# Altium schematic sheet EPS_connector.SchDoc
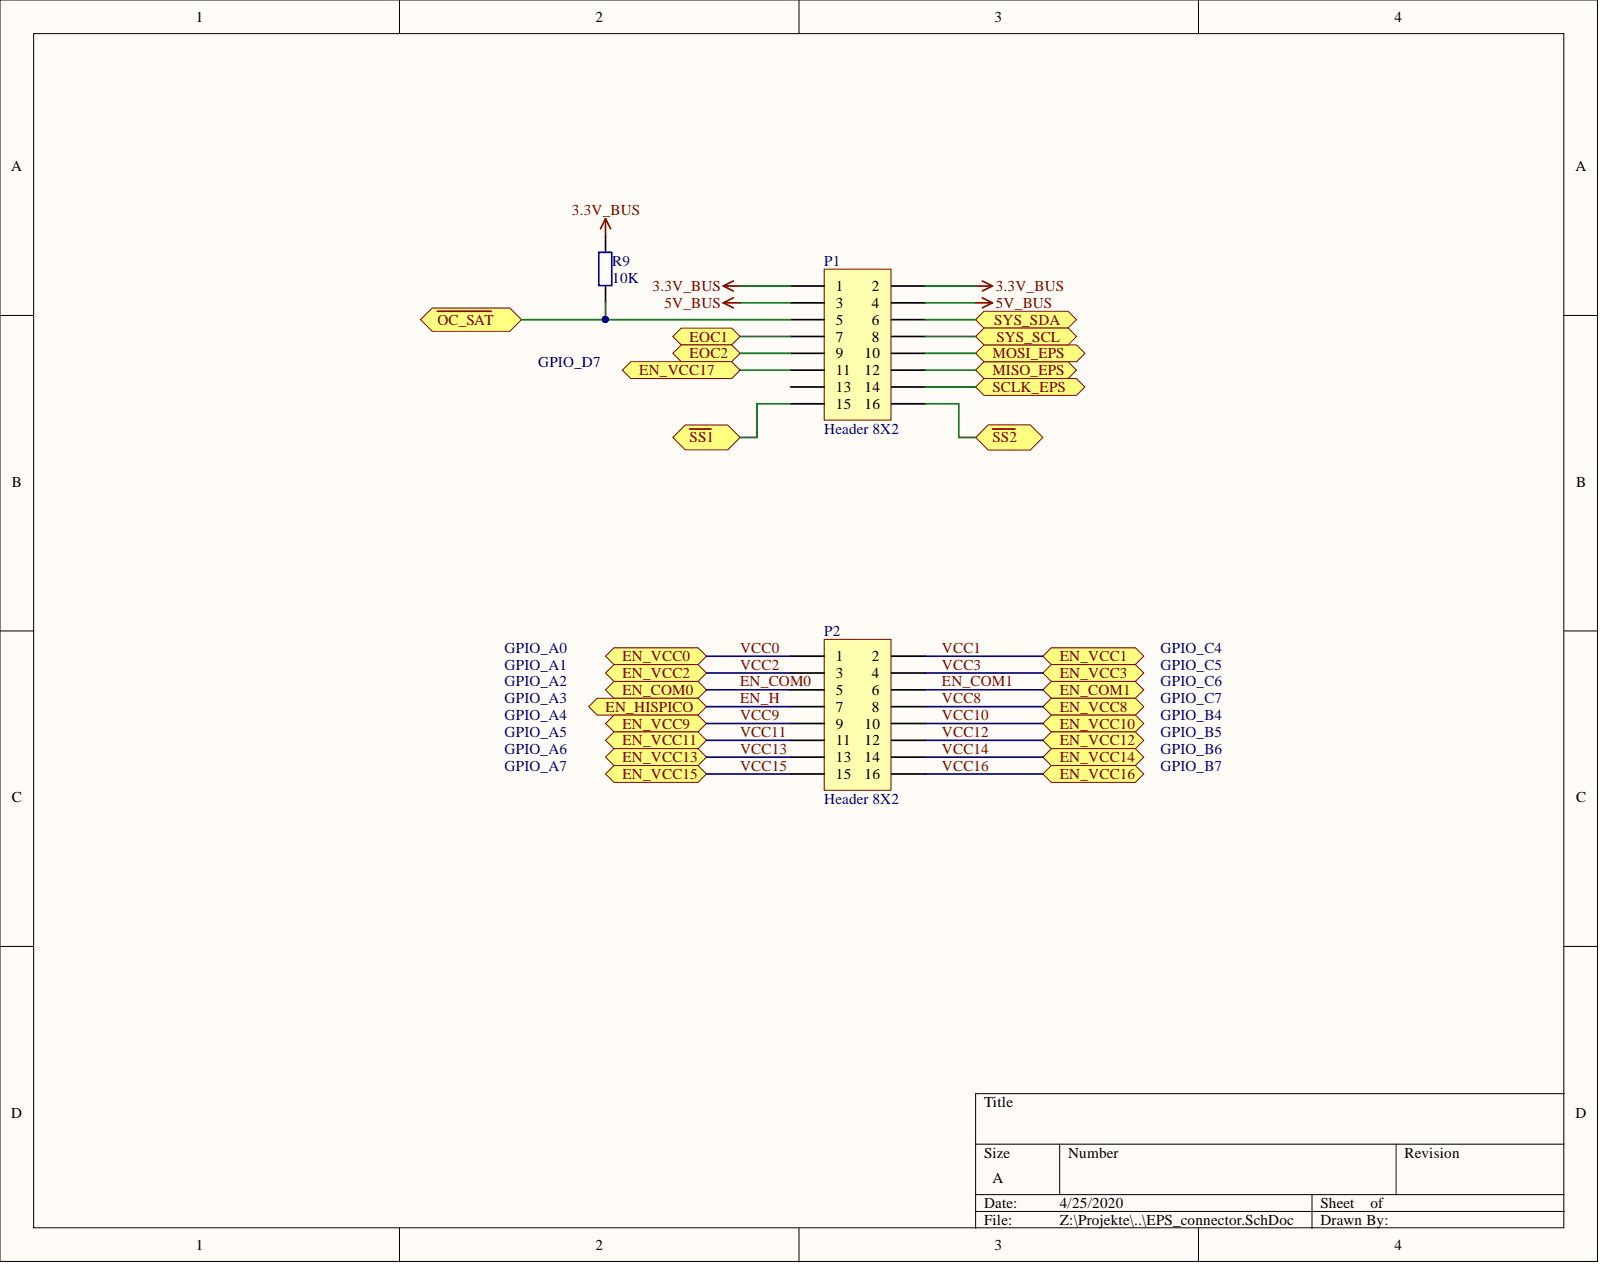
[diagram p0: EPS_connector.SchDoc]

## Parts
P1  Header 8X2  [HDR2X8]
P2  Header 8X2  [HDR2X8]
R9  10K  [1608[0603]]

## Ports
EN_COM0, EN_COM1, EN_HISPICO, EN_VCC0, EN_VCC1, EN_VCC2, EN_VCC3, EN_VCC8, EN_VCC9, EN_VCC10, EN_VCC11, EN_VCC12, EN_VCC13, EN_VCC14, EN_VCC15, EN_VCC16, EN_VCC17, EOC1, EOC2, MISO_EPS, MOSI_EPS, SCLK_EPS, SYS_SCL, SYS_SDA, ~{OC_SAT}, ~{SS1}, ~{SS2}

## Nets
3.3V_BUS: P1.1 P1.2 R9.2
5V_BUS: P1.3 P1.4
EN_COM0: P2.5 ; port EN_COM0
EN_COM1: P2.6 ; port EN_COM1
EN_H: P2.7 ; port EN_HISPICO
EN_VCC17: P1.11 ; port EN_VCC17
EOC1: P1.7 ; port EOC1
EOC2: P1.9 ; port EOC2
MISO_EPS: P1.12 ; port MISO_EPS
MOSI_EPS: P1.10 ; port MOSI_EPS
~{OC_SAT}: P1.5 R9.1 ; port ~{OC_SAT}
~{SS1}: P1.15 ; port ~{SS1}
~{SS2}: P1.16 ; port ~{SS2}
SCLK_EPS: P1.14 ; port SCLK_EPS
SYS_SCL: P1.8 ; port SYS_SCL
SYS_SDA: P1.6 ; port SYS_SDA
VCC0: P2.1 ; port EN_VCC0
VCC1: P2.2 ; port EN_VCC1
VCC2: P2.3 ; port EN_VCC2
VCC3: P2.4 ; port EN_VCC3
VCC8: P2.8 ; port EN_VCC8
VCC9: P2.9 ; port EN_VCC9
VCC10: P2.10 ; port EN_VCC10
VCC11: P2.11 ; port EN_VCC11
VCC12: P2.12 ; port EN_VCC12
VCC13: P2.13 ; port EN_VCC13
VCC14: P2.14 ; port EN_VCC14
VCC15: P2.15 ; port EN_VCC15
VCC16: P2.16 ; port EN_VCC16

Not connected: P1 13

## Library data of the parts
P1 P2 = Header 8X2: Header, 8-Pin, Dual row; Component Kind: Standard; LatestRevisionDate: 17-Jul-2002; LatestRevisionNote: Re-released for DXP Platform.; Library Name: Miscellaneous Connectors.IntLib; Signal Integrity: Connector; Publisher: Altium Limited
R9 = Res2: Resistor; Component Kind: Standard; LatestRevisionDate: 17-Jul-2002; LatestRevisionNote: Re-released for DXP Platform.; Library Name: Miscellaneous Devices.IntLib; PackageDescription: Axial Device, Thru-Hole; 2 Leads; 0.4 in Pin Spacing; PackageReference: AXIAL-0.4; Published: 8-Jun-2000; Signal Integrity: Res; Simulation: RESISTOR; Value: 10K; Publisher: Altium Limited
